# Altium schematic sheet PowerMonitor.SchDoc
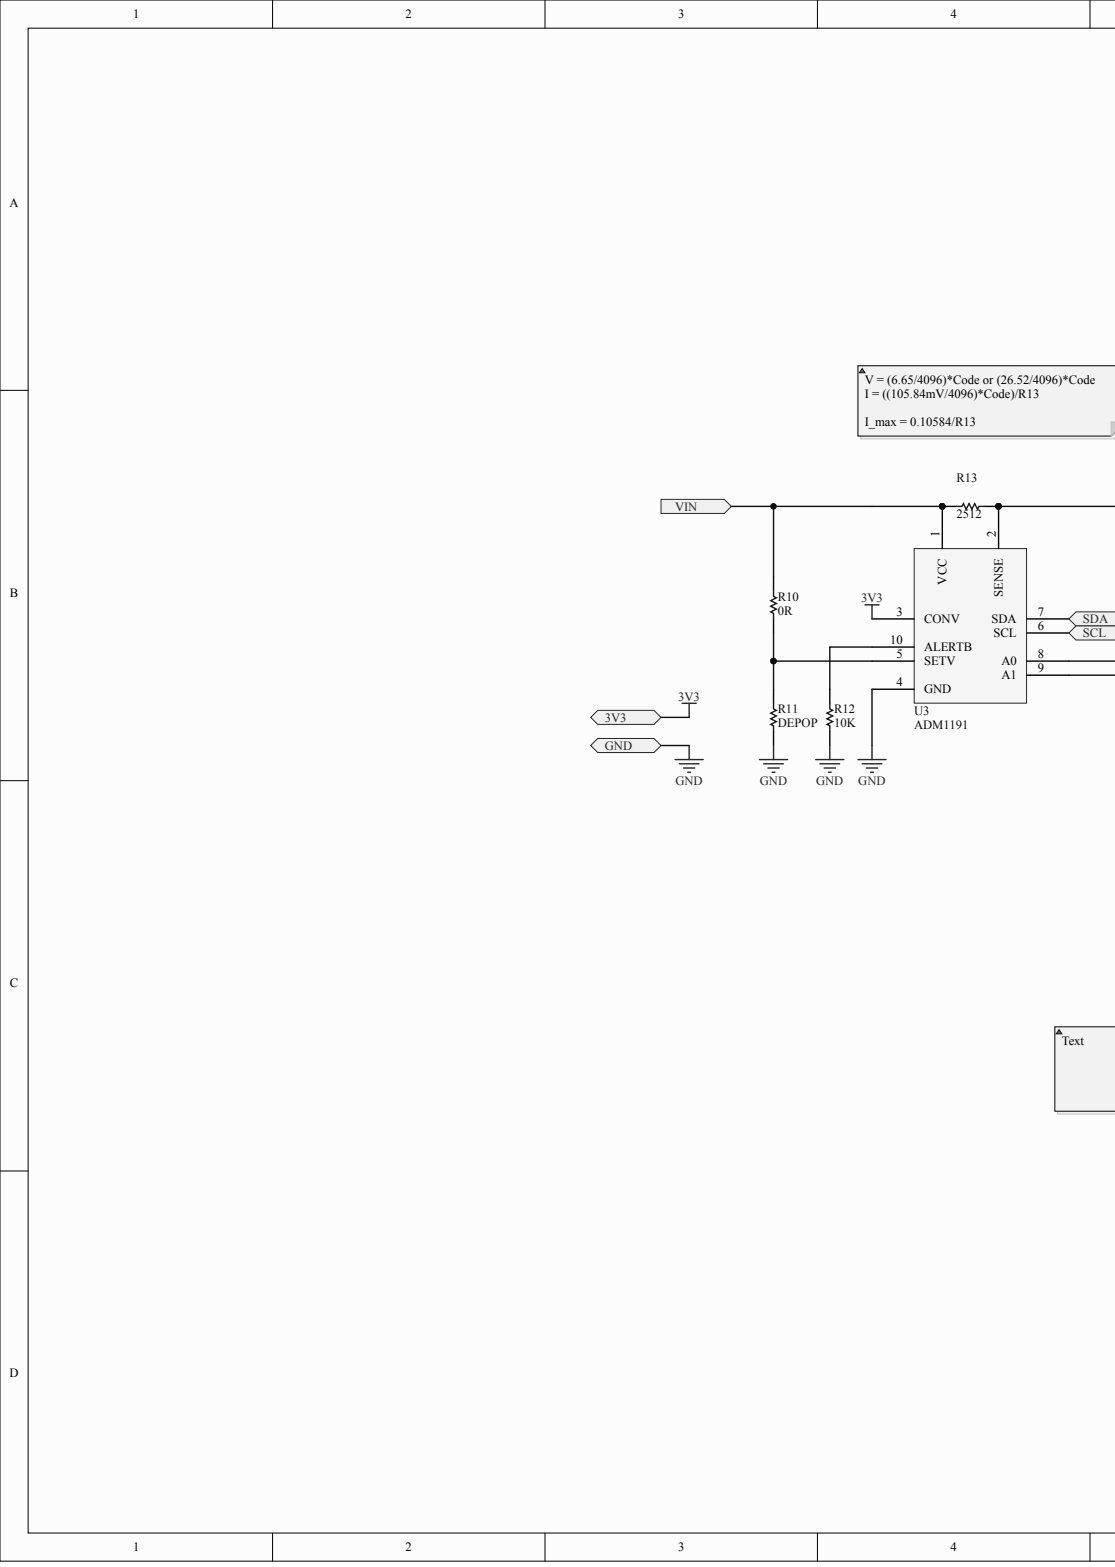
[diagram p0: PowerMonitor.SchDoc, left]
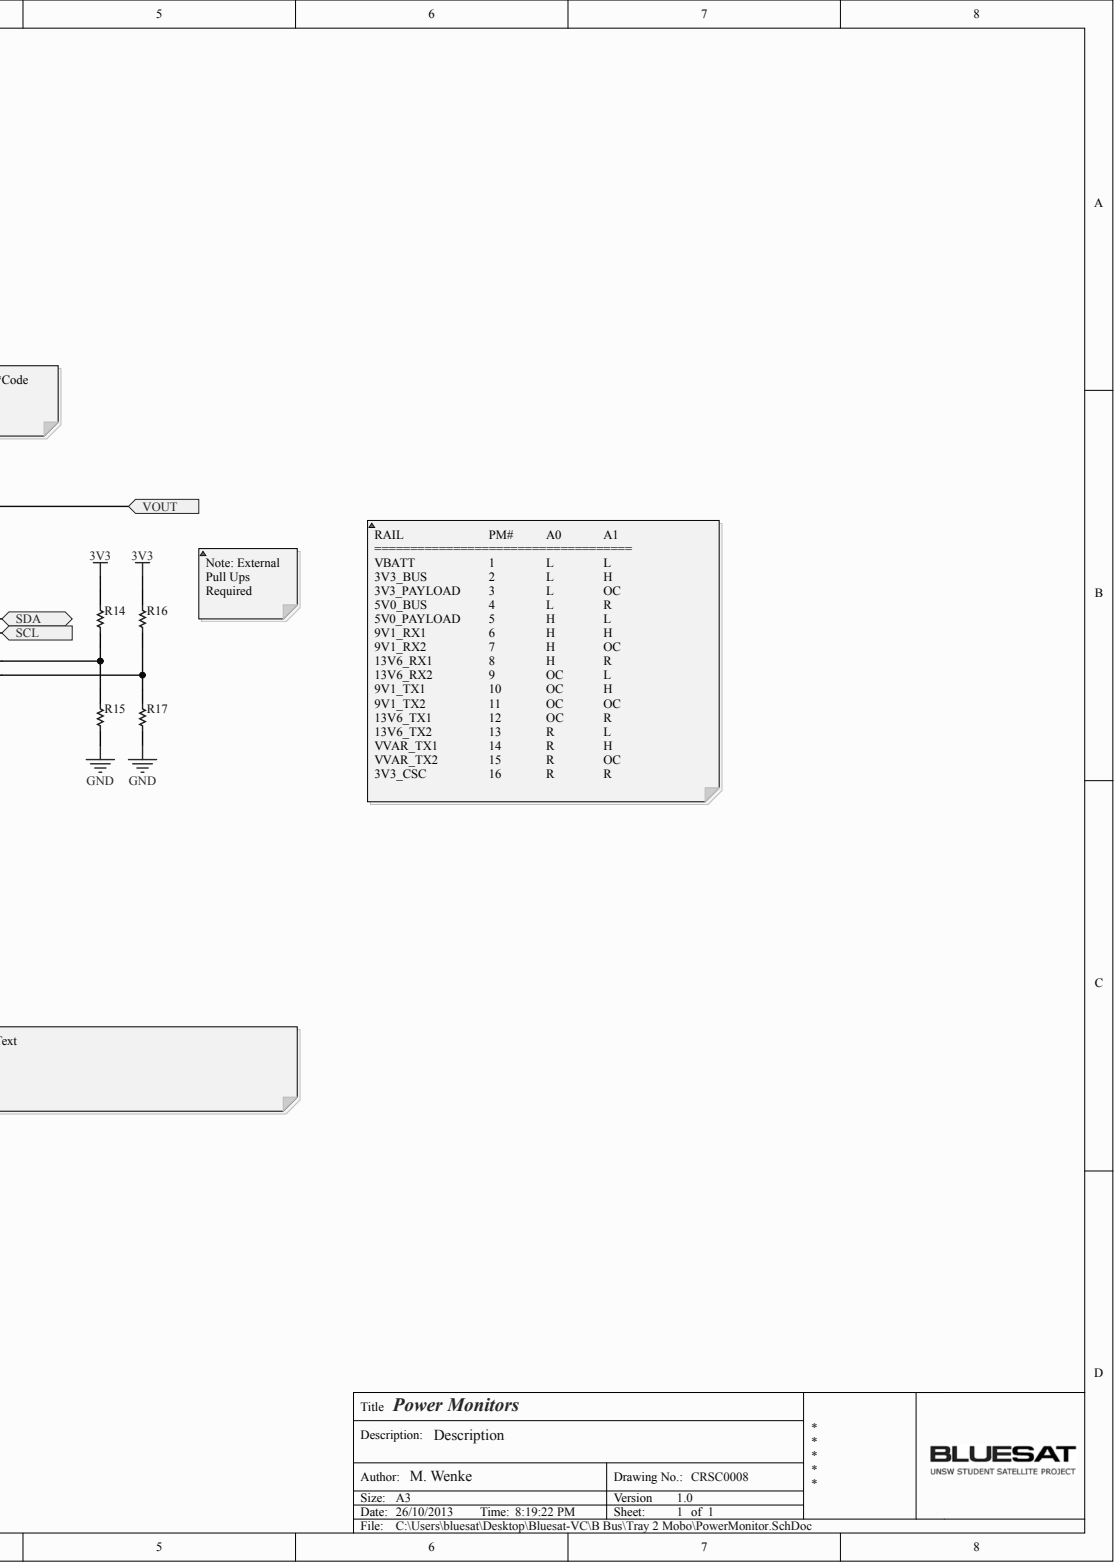
[diagram p1: PowerMonitor.SchDoc, right]

## Parts
R10  value 0R
R11  value DEPOP
R12  value 10K
R13  (no value)  [2512]
R14  (no value)
R15  (no value)
R16  (no value)
R17  (no value)
U3  ADM1191

## Ports
3V3, GND, SCL, SDA, VIN, VOUT

## Nets
3V3: R14.1 R16.1 U3.3(CONV) ; port 3V3
GND: R11.2 R12.2 R15.2 R17.2 U3.4(GND) ; port GND
SCL: U3.6(SCL) ; port SCL
SDA: U3.7(SDA) ; port SDA
VIN: R10.1 R13.2 U3.1(VCC) ; port VIN
VOUT: R13.1 U3.2(SENSE) ; port VOUT
NetR10_2: R10.2 R11.1 U3.5(SETV)
NetR12_1: R12.1 U3.10(ALERTB)
NetR14_2: R14.2 R15.1 U3.8(A0)
NetR16_2: R16.2 R17.1 U3.9(A1)

## Library data of the parts
R13-R17 = (no symbol name): Value: 1K; Published: 8-Jun-2000; LatestRevisionDate: 17-Jul-2002; LatestRevisionNote: Re-released for DXP Platform.; PackageReference: AXIAL-0.3; PackageDescription: Axial Device, Thru-Hole; 2 Leads; 0.3 in Pin Spacing; Publisher: Altium Limited
R10-R12 U3 = (no symbol name)
  R10-R12: Published: 8-Jun-2000; LatestRevisionDate: 17-Jul-2002; LatestRevisionNote: Re-released for DXP Platform.; PackageReference: AXIAL-0.3; PackageDescription: Axial Device, Thru-Hole; 2 Leads; 0.3 in Pin Spacing; Publisher: Altium Limited
